# Altium schematic sheet Alimentacion.SchDoc(Alimentacion)
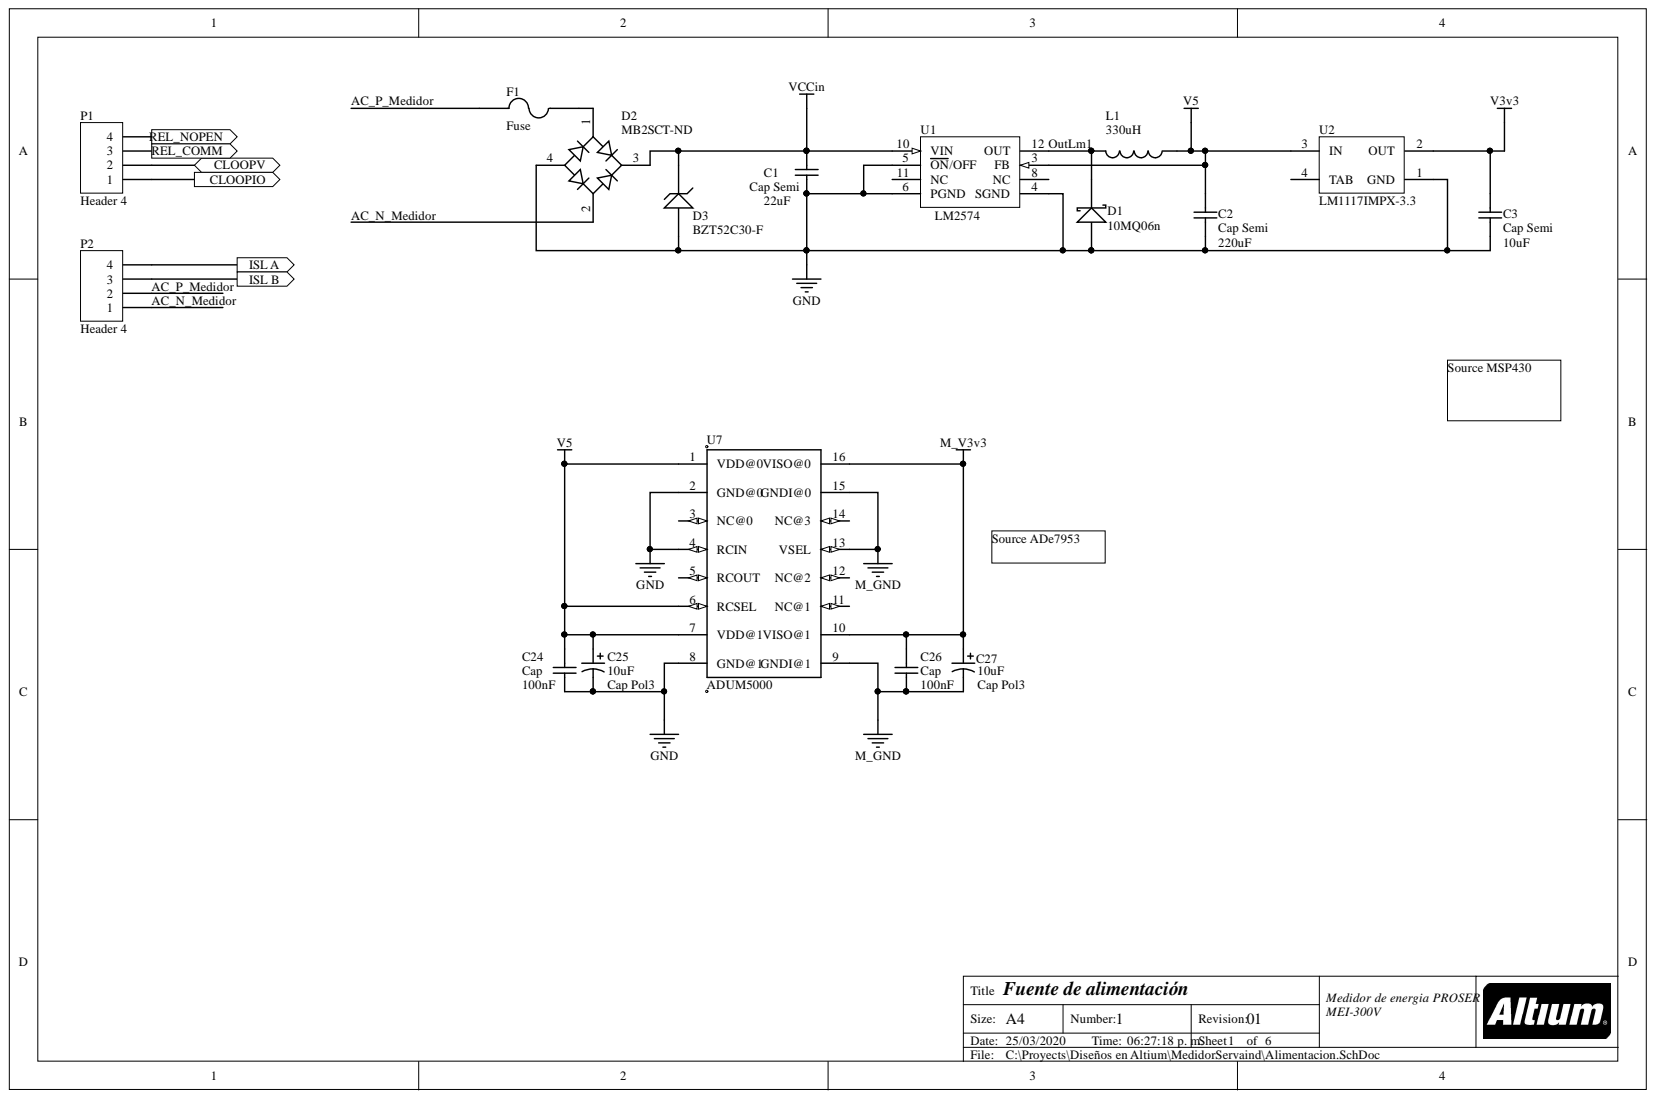
[diagram p0: Alimentacion.SchDoc]

## Parts
C1  Cap Semi  value 22uF  [7343]
C2  Cap Semi  value 220uF  [7343]
C3  Cap Semi  value 10uF  [3528]
C24  Cap  value 100nF  [Custom0805]
C25  Cap Pol3  value 10uF  [3528]
C26  Cap  value 100nF  [Custom0805]
C27  Cap Pol3  value 10uF  [3528]
D1  10MQ06n  [DO214]
D2  MB2SCT-ND  [SOIC4_M]
D3  BZT52C30-F  [1N4148W]
F1  Fuse  [SMD030F]
L1  value 330uH  [PE-526XXNL]  (hidden comment: Inductor)
P1  Header 4  [ConectorPrsr]
P2  Header 4  [ConectorPrsr]
U1  LM2574  [SOIC127P1033X264-14N]
U2  LM1117IMPX-3.3  [MP04A_N]
U7  ADUM5000  [*SO16W]

## Ports
CLOOPIO, CLOOPV, ISL A, ISL B, REL_COMM, REL_NOPEN

## Nets
GND: C1.1 C2.1 C3.1 C24.1 C25.2 D1.1 D2.4 D3.1 U1.4(SGND) U1.5(ON/OFF) U1.6(PGND) U2.1(GND) U7.2(GND@0) U7.4(RCIN) U7.8(GND@1)
M_GND: C26.1 C27.2 U7.9(GNDI@1) U7.13(VSEL) U7.15(GNDI@0)
M_V3V3: C26.2 C27.1 U7.10(VISO@1) U7.16(VISO@0)
V3V3: C3.2 U2.2(OUT)
V5: C2.2 C24.2 C25.1 L1.1 U1.3(FB) U2.3(IN) U7.1(VDD@0) U7.6(RCSEL) U7.7(VDD@1)
VCCIN: C1.2 D2.3 D3.2 U1.10(VIN)
AC_N_MEDIDOR: D2.2 P2.1
AC_P_MEDIDOR: F1.1 P2.2
ISL A: P2.4 ; port ISL A
ISL B: P2.3 ; port ISL B
OUTLM1: D1.2 L1.2 U1.12(OUT)
NetD2_1: D2.1 F1.2
NetP1_1: P1.1 ; port CLOOPIO
NetP1_2: P1.2 ; port CLOOPV
NetP1_3: P1.3 ; port REL_COMM
NetP1_4: P1.4 ; port REL_NOPEN

Not connected: U1 8(NC) 11(NC); U2 4(TAB); U7 3(NC@0) 5(RCOUT) 11(NC@1) 12(NC@2) 14(NC@3)

## Library data of the parts
C1-C3 = Cap Semi: Capacitor (Semiconductor SIM Model); Component Kind: Standard; LatestRevisionDate: 29-May-2009; LatestRevisionNote: IPC-7351 Footprint Added.; Library Name: Miscellaneous Devices.IntLib; PackageDescription: Chip Capacitor; PackageReference: C1206; Published: 8-Jun-2000; Signal Integrity: Cap Semi; Simulation: CAP; Publisher: Altium Limited
C24 C26 = Cap: Capacitor; Component Kind: Standard; LatestRevisionDate: 17-Jul-2002; LatestRevisionNote: Re-released for DXP Platform.; Library Name: Miscellaneous Devices.IntLib; PackageDescription: Radial Cap, Thru-Hole; 2 Leads; 0.3 in Pin Spacing; PackageReference: RAD-0.3; Published: 8-Jun-2000; Signal Integrity: Cap; Simulation: CAP; Publisher: Altium Limited
C25 C27 = Cap Pol3: Polarized Capacitor (Surface Mount); Component Kind: Standard; LatestRevisionDate: 29-May-2009; LatestRevisionNote: IPC-7351 Footprint Added.; Library Name: Miscellaneous Devices.IntLib; PackageDescription: Chip Capacitor; PackageReference: C0805; Published: 8-Jun-2000; Signal Integrity: Cap Pol; Simulation: CAP; Publisher: Altium Limited
D1 = D Schottky: Schottky Diode; Code_JEDEC: DO-214-AA; Component Kind: Standard; LatestRevisionDate: 17-Jul-2002; LatestRevisionNote: Re-released for DXP Platform.; Library Name: Miscellaneous Devices.IntLib; PackageDescription: DO-214-AA/SMB; 2 C-Bend Leads; Body 5.3 x 3.6 mm, inc. leads (LxW); PackageReference: SMB; Published: 8-Jun-2000; Signal Integrity: D Schottky; Simulation: SKYDIODE; Publisher: Altium Limited
D2 = Bridge1: Full Wave Diode Bridge - MB2S; Component Kind: Standard; DatasheetVersion: E2732B; LatestRevisionDate: 29-May-2009; LatestRevisionNote: Sch pins A & K swapped.; Library Name: Miscellaneous Devices.IntLib; PackageDescription: Bridge Rectifier; 4 Terminals; Body 9.4 x 9.4 mm (typ); Pitch 5.08 mm; PackageReference: D-38; Published: 8-Jun-2000; Simulation: BRIDGE; Publisher: Altium Limited
D3 = D Zener: Zener Diode SMD; Component Kind: Standard; LatestRevisionDate: 17-Jul-2002; LatestRevisionNote: Re-released for DXP Platform.; Library Name: Miscellaneous Devices.IntLib; PackageDescription: Diode, Thru-Hole; 2 Leads; 0.7 in Pin Spacing; PackageReference: DIODE-0.7; Published: 8-Jun-2000; Signal Integrity: D Zener; Simulation: ZENER; Publisher: Altium Limited
F1 = Fuse 2: SMD030-2CT-ND; Component Kind: Standard; LatestRevisionDate: 17-Jul-2002; LatestRevisionNote: Re-released for DXP Platform.; Library Name: Miscellaneous Devices.IntLib; PackageDescription: Thru-Hole; 2 Leads; PackageReference: PIN-W2/E2.8; Published: 8-Jun-2000; Simulation: FUSE; Publisher: Altium Limited
L1 = Inductor: PE52627NL; Code_IEC: 1005; Code_JEITA: 0402; Component Kind: Standard; LatestRevisionDate: 29-May-2009; LatestRevisionNote: IPC-7351 Footprint Added.; Library Name: Miscellaneous Devices.IntLib; PackageDescription: Chip Inductor; PackageReference: 0402-A; Published: 8-Jun-2000; Signal Integrity: Inductor; Simulation: INDUCTOR; Publisher: Altium Limited
P1 P2 = Header 4: Header, 4-Pin; Component Kind: Standard; LatestRevisionDate: 17-Jul-2002; LatestRevisionNote: Re-released for DXP Platform.; Library Name: Miscellaneous Connectors.IntLib; Signal Integrity: Connector; Publisher: Altium Limited
U1 = LM2574N-5: Easy Switcher 0.5A Step-Down Voltage Regulator; Component Kind: Standard; ComponentLink1Description: Manufacturer Link; ComponentLink1URL: http://www.onsemi.com/site/products/taxonomy/0,4438,503,00.html; ComponentLink2Description: Datasheet; ComponentLink2URL: http://www.onsemi.com/pub/Collateral/LM2574-D.PDF; DatasheetVersion: Jul-1999; Library Name: ON Semi Power Mgt Voltage Regulator.IntLib; PackageDescription: DIP; 8 Leads; Row Spacing 7.62 mm; Pitch 2.54 mm; PackageReference: 626-05; PackageVersion: 1998; Published: 15-Apr-2002; Publisher: Altium Limited
U2 = LM1117IMPX-3.3: 800mA Low-Dropout Linear Regulator, 4-pin SOT-223; Manufacturer: National Semiconductor; CompType: LDO IC; PackageTopMarking: XYTT*N05B; PowerWise: N; Code_IPC: SOT223-4; Code_JEDEC: TO-261-AA; Component Kind: Standard; ComponentLink1Description: LM1117 Datasheet; ComponentLink1URL: http://www.national.com/ds/LM/LM1117.pdf; ComponentLink2Description: LM1117 Web Page; ComponentLink2URL: http://www.national.com/pf/LM/LM1117.html; ComponentLink3Description: MP04A Package Page; ComponentLink3URL: http://www.national.com/packaging/folders/mp04a.html; DatasheetVersion: Apr-2006; Library Name: NSC LDO.IntLib; PackageDescription: Molded SOT-223, 6.50 x 3.56 x 1.6mm Body, 4 Lead, 2.3mm Pitch; PackageReference: MP04A; PackageVersion: revB, Apr-2003; PartNumber: LM1117IMPX-3.3; PinCount: 4; Published: 1-Mar-2010; RoHS: N; Publisher: Altium Limited
U7 = ADUM5000: ADI Isolated DC/DC Converter; MF: Analog Devices; Availability: Unavailable; Component Kind: Standard; Library Name: ADUM5000.IntLib; MP: ADUM5000; Package: None; Price: None; SnapEDA_Link: https://www.snapeda.com/parts/ADUM5000/Analog%20Devices%20Inc./view-part/?ref=snap
